# Altium schematic sheet actuators-top.SchDoc
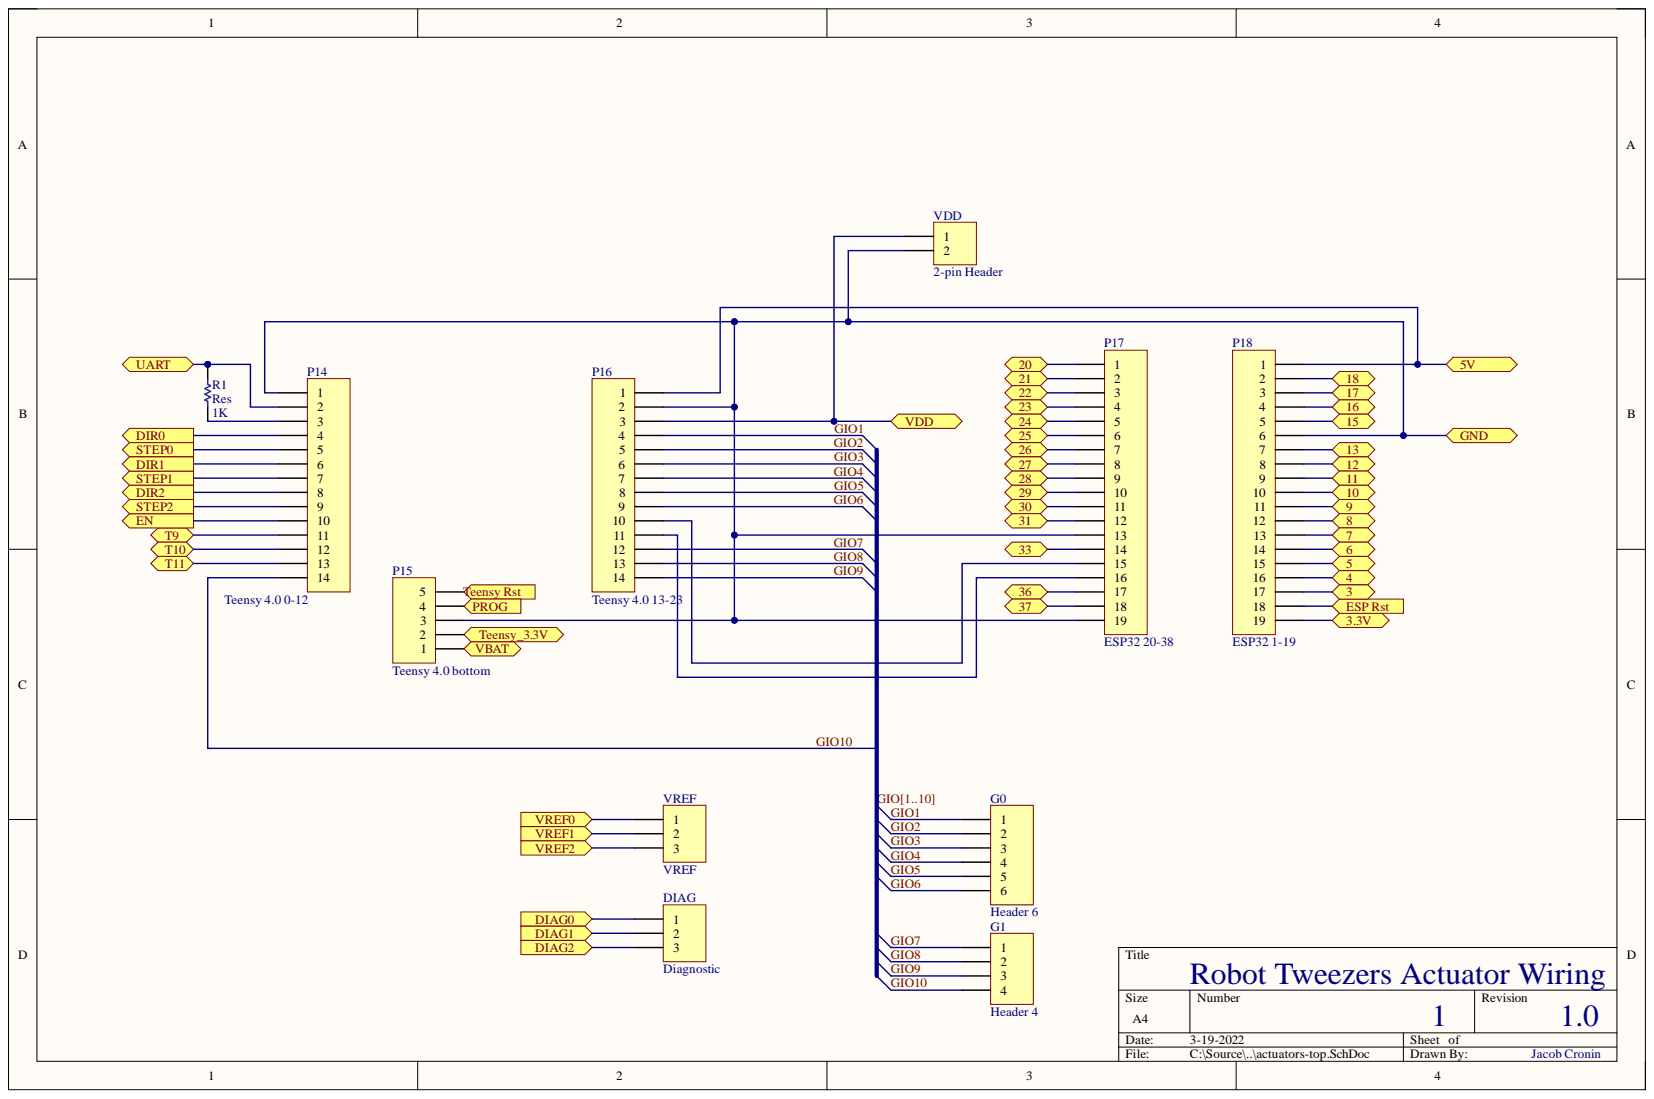
[diagram p0: actuators-top.SchDoc]

## Parts
DIAG  Diagnostic  [HDR1X3]
G0  Header 6  [HDR1X6]
G1  Header 4  [HDR1X4]
P14  Teensy 4.0 0-12  [HDR1X14]
P15  Teensy 4.0 bottom  [HDR1X5]
P16  Teensy 4.0 13-23  [HDR1X14]
P17  ESP32 20-38  [HDR1X19]
P18  ESP32 1-19  [HDR1X19]
R1  Res  value 1K  [AXIAL-0.3]
VDD  2-pin Header  [HDR1X2]
VREF  VREF  [HDR1X3]

## Ports
3, 3.3V, 4, 5, 5V, 6, 7, 8, 9, 10, 11, 12, 13, 15, 16, 17, 18, 20, 21, 22, 23, 24, 25, 26, 27, 28, 29, 30, 31, 33, 36, 37, DIAG0, DIAG1, DIAG2, DIR0, DIR1, DIR2, EN, ESP Rst, GND, PROG, STEP0, STEP1, STEP2, T9, T10, T11, Teensy Rst, Teensy_3.3V, UART, VBAT, VDD, VREF0, VREF1, VREF2

## Nets
3: P18.17 ; port 3
3.3V: P18.19 ; port 3.3V
4: P18.16 ; port 4
5: P18.15 ; port 5
5V: P16.1 P18.1 ; port 5V
6: P18.14 ; port 6
7: P18.13 ; port 7
8: P18.12 ; port 8
9: P18.11 ; port 9
10: P18.10 ; port 10
11: P18.9 ; port 11
12: P18.8 ; port 12
13: P18.7 ; port 13
15: P18.5 ; port 15
16: P18.4 ; port 16
17: P18.3 ; port 17
18: P18.2 ; port 18
20: P17.1 ; port 20
21: P17.2 ; port 21
22: P17.3 ; port 22
23: P17.4 ; port 23
24: P17.5 ; port 24
25: P17.6 ; port 25
26: P17.7 ; port 26
27: P17.8 ; port 27
28: P17.9 ; port 28
29: P17.10 ; port 29
30: P17.11 ; port 30
31: P17.12 ; port 31
33: P17.14 ; port 33
36: P17.17 ; port 36
37: P17.18 ; port 37
DIAG0: DIAG.1 ; port DIAG0
DIAG1: DIAG.2 ; port DIAG1
DIAG2: DIAG.3 ; port DIAG2
DIR0: P14.4 ; port DIR0
DIR1: P14.6 ; port DIR1
DIR2: P14.8 ; port DIR2
EN: P14.10 ; port EN
ESP Rst: P18.18 ; port ESP Rst
GIO1: G0.1 P16.4  [also labelled GIO]
GIO2: G0.2 P16.5  [also labelled GIO]
GIO3: G0.3 P16.6  [also labelled GIO]
GIO4: G0.4 P16.7  [also labelled GIO]
GIO5: G0.5 P16.8  [also labelled GIO]
GIO6: G0.6 P16.9  [also labelled GIO]
GIO7: G1.1 P16.12  [also labelled GIO]
GIO8: G1.2 P16.13  [also labelled GIO]
GIO9: G1.3 P16.14  [also labelled GIO]
GIO10: G1.4 P14.14  [also labelled GIO]
GND: P14.1 P15.3 P16.2 P17.13 P17.19 P18.6 VDD.2 ; port GND
PROG: P15.4 ; port PROG
STEP0: P14.5 ; port STEP0
STEP1: P14.7 ; port STEP1
STEP2: P14.9 ; port STEP2
T9: P14.11 ; port T9
T10: P14.12 ; port T10
T11: P14.13 ; port T11
Teensy Rst: P15.5 ; port Teensy Rst
Teensy_3.3V: P15.2 ; port Teensy_3.3V
UART: P14.2 R1.2 ; port UART
VBAT: P15.1 ; port VBAT
VDD: P16.3 VDD.1 ; port VDD
VREF0: VREF.1 ; port VREF0
VREF1: VREF.2 ; port VREF1
VREF2: VREF.3 ; port VREF2
NetP14_3: P14.3 R1.1
NetP16_10: P16.10 P17.15
NetP16_11: P16.11 P17.16

## Library data of the parts
DIAG VREF = Header 3: Header, 3-Pin; Component Kind: Standard; LatestRevisionDate: 17-Jul-2002; LatestRevisionNote: Re-released for DXP Platform.; Library Name: Miscellaneous Connectors.IntLib; Signal Integrity: Connector; Publisher: Altium Limited
G0 = Header 6: Header, 6-Pin; Component Kind: Standard; LatestRevisionDate: 17-Jul-2002; LatestRevisionNote: Re-released for DXP Platform.; Library Name: Miscellaneous Connectors.IntLib; Signal Integrity: Connector; Publisher: Altium Limited
G1 = Header 4: Header, 4-Pin; Component Kind: Standard; LatestRevisionDate: 17-Jul-2002; LatestRevisionNote: Re-released for DXP Platform.; Library Name: Miscellaneous Connectors.IntLib; Signal Integrity: Connector; Publisher: Altium Limited
P14 P16 = Header 14: Header, 14-Pin; Component Kind: Standard; LatestRevisionDate: 17-Jul-2002; LatestRevisionNote: Re-released for DXP Platform.; Library Name: miscellaneous_connectors.IntLIb; Signal Integrity: Connector; Publisher: Altium Limited
P15 = Header 5: Header, 5-Pin; Component Kind: Standard; LatestRevisionDate: 17-Jul-2002; LatestRevisionNote: Re-released for DXP Platform.; Library Name: miscellaneous_connectors.IntLIb; Signal Integrity: Connector; Publisher: Altium Limited
P17 P18 = Header 19: Header, 19-Pin; Component Kind: Standard; LatestRevisionDate: 17-Jul-2002; LatestRevisionNote: Re-released for DXP Platform.; Library Name: miscellaneous_connectors.IntLIb; Signal Integrity: Connector; Publisher: Altium Limited
R1 = Res1: Resistor; Component Kind: Standard; LatestRevisionDate: 17-Jul-2002; LatestRevisionNote: Re-released for DXP Platform.; Library Name: Miscellaneous Devices.IntLib; PackageDescription: Axial Device, Thru-Hole; 2 Leads; 0.3 in Pin Spacing; PackageReference: AXIAL-0.3; Published: 8-Jun-2000; Signal Integrity: Res; Simulation: RESISTOR; Publisher: Altium Limited
VDD = Header 2: Header, 2-Pin; Component Kind: Standard; LatestRevisionDate: 17-Jul-2002; LatestRevisionNote: Re-released for DXP Platform.; Library Name: Miscellaneous Connectors.IntLib; Signal Integrity: Connector; Publisher: Altium Limited
